# Altium schematic sheet Monitor Blanking V3.SchDoc
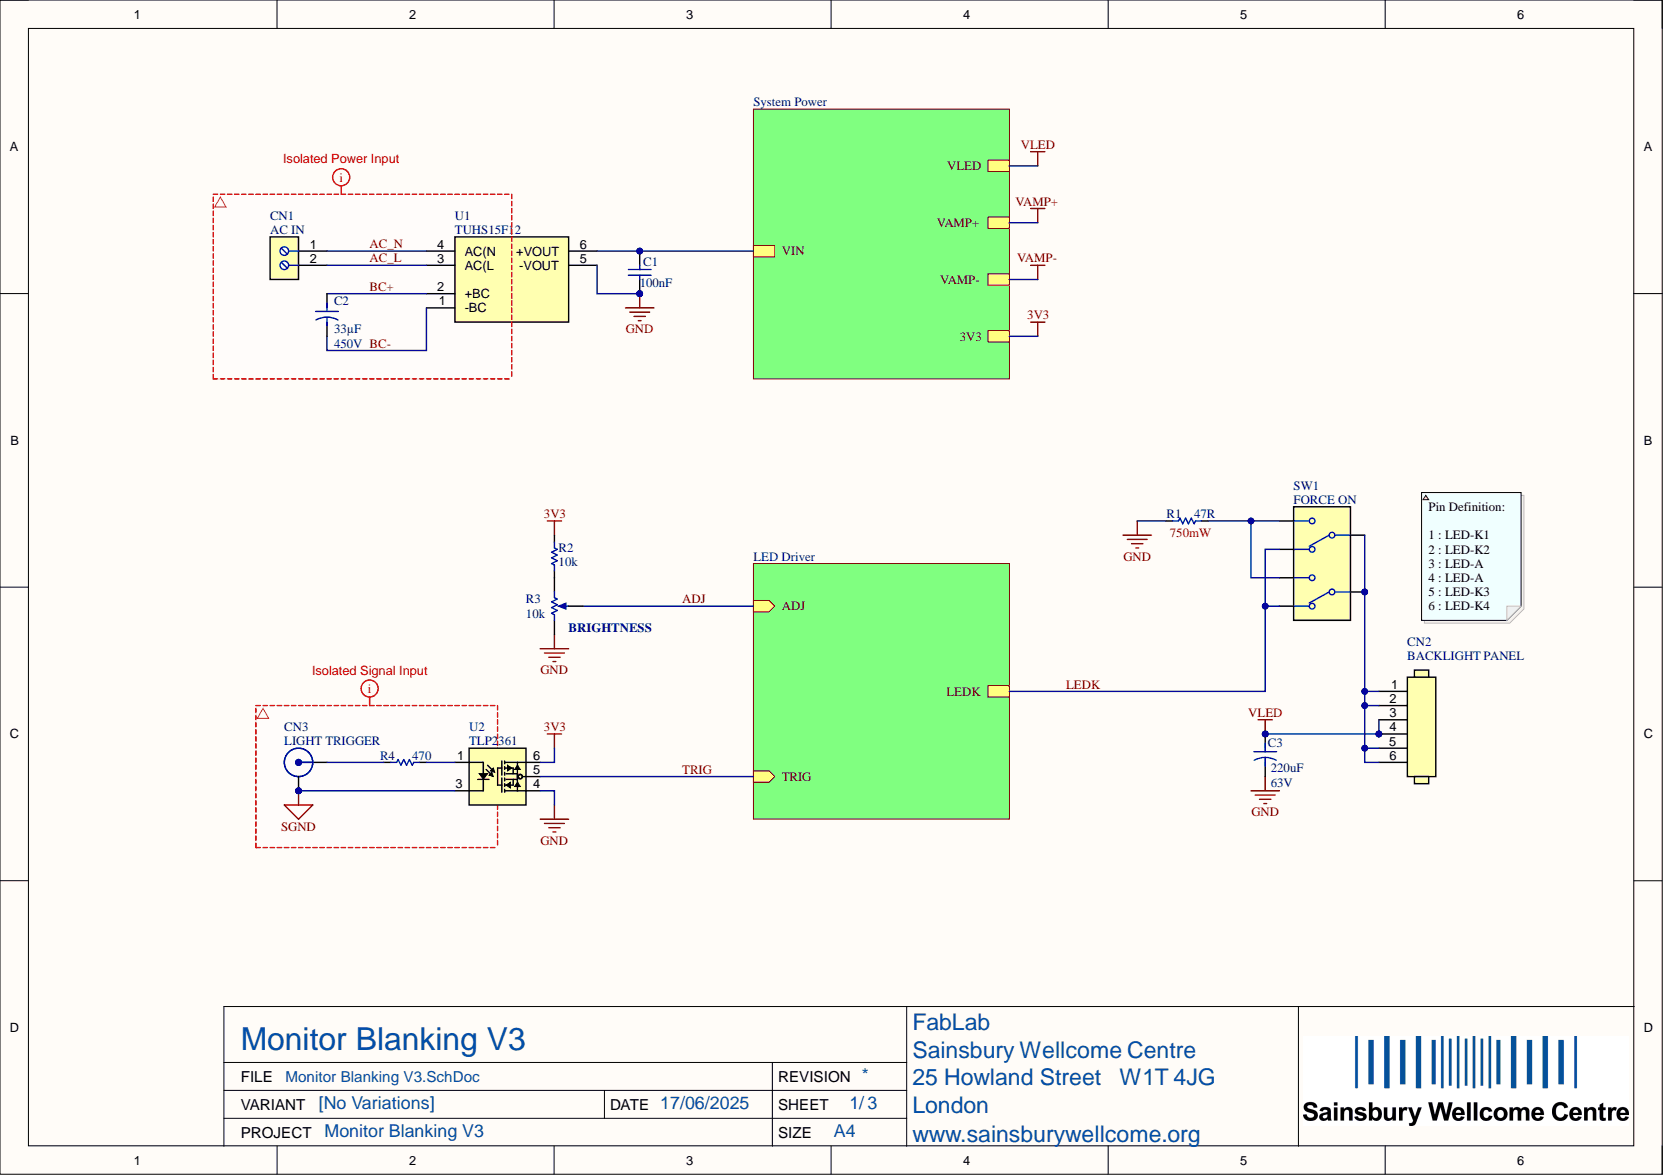
[diagram p0: Monitor Blanking V3.SchDoc]

## Parts
C1  value 100nF  [CAP_CHIP_0603]  (hidden comment: C0603-100nF-50V)
C2  value 33µF  Voltage Rating: 450V  [ECAP_DIP_12.5_90]  (hidden comment: EC125-33uF-450V)
C3  value 220uF  Voltage Rating: 63V  [ECAP_SMD_D12.5_13.5L]  (hidden comment: EC125-220uF-63V)
CN1  AC IN  [BT-8.26-1X2P]
CN2  BACKLIGHT PANEL  [PH_DIP_90_1X6P]
CN3  LIGHT TRIGGER  [BNC-TE-5227222]
R1  value 47R  Power Rating: 750mW  [RES_CHIP_2010]  (hidden comment: R2010-47R)
R2  value 10k  [RES_CHIP_0603]  (hidden comment: R0603-10K)
R3  BRIGHTNESS  value 10k  [RK09L1140]
R4  value 470  [RES_CHIP_0603]  (hidden comment: R0603-470R)
SW1  FORCE ON  [SW_LOCK_SPPH410100]
U1  TUHS15F12  [TUHS15F12]
U2  TLP2361  [SO5]

## Nets
3V3: R2.2 U2.6
GND: C1.1 C3.2 R1.1 R3.1 U1.5(-VOUT) U2.4
SGND: CN3.2 U2.3
VLED: C3.1 CN2.3 CN2.4
AC_L: CN1.2 U1.3(AC(L)
AC_N: CN1.1 U1.4(AC(N)
ADJ: R3.2
BC+: C2.1 U1.2(+BC)
BC-: C2.2 U1.1(-BC)
LEDK: SW1.1 SW1.4
TRIG: U2.5
NetC1_2: C1.2 U1.6(+VOUT)
NetCN2_1: CN2.1 CN2.2 CN2.5 CN2.6 SW1.2 SW1.5
NetCN3_1: CN3.1 R4.1
NetR1_2: R1.2 SW1.3 SW1.6
NetR2_1: R2.1 R3.3
NetR4_2: R4.2 U2.1
VAMP+:
VAMP-:

## Library data of the parts
C1 = CMP-611-0027-24: 0603 Multilayer Ceramic Capacitors MLCC; PartNumber: CC0603KRX7R9BB104; Dielectric: X7R; Pick&PlaceFootprint: C0603; Tolerance: 10%; Voltage Rating: 50V; Capacitor Type: Ceramic; Case/Package: 0603; Component Kind: Standard; LCSC Part N.: C14663; Mount: SMD; RoHS: Yes; Library Name: Sainsbury Wellcome Centre
C2 = CMP-612-0013-1: Aluminum Electrolytic Capacitor, Polarized, Aluminum (wet), 450V, 20% +Tol, 20% -Tol, 33uF, Through Hole Mount; PartNumber: 450BXW33MEFR12.5X25; Capacitor Type: Aluminium; Component Kind: Standard; LCSC Part N.: C1589601; Mount: TH; Tolerance: 20%; Library Name: Sainsbury Wellcome Centre
C3 = CMP-612-0011-1: Radial Can - SMD ALUMINUM ELECTROLYTIC CAPACITOR FK 63V 220muF 20% 0.492Dia 12.50mm; PartNumber: EEEFK1J221AQ; ESR (Equivalent Series Resistance): 160mR; Ripple Current: 800mA; Capacitor Type: Electrolytic; Component Kind: Standard; LCSC Part N.: C215762; Tolerance: 20%; Library Name: Sainsbury Wellcome Centre
CN1 = CMP-330-0001-1: CONN BARRIER STRIP 2CIRC 0.325"; PartNumber: 4DB-P108-02; Current Rating: 20A; Gender: Male; Max Current Rating: 10A; Number of Contacts: 2; Pitch: 8.26mm; Voltage Rating: 300V; Component Kind: Standard; LCSC Part N.: C5902324; Number of Positions: 2; Number of Rows: 1; Orientation: Straight; Library Name: Sainsbury Wellcome Centre
CN2 = CMP-370-0048-1: Wire-To-Board Connector, Right Angle, 2 mm, 2 Contacts, Header, PH Series; PartNumber: X2016WR-06-46SN; Gender: Male; Max Current Rating: 2A; Max Voltage Rating (DC): 100V; Component Kind: Standard; LCSC Part N.: C696999; Lead Pitch: 2mm; Mount: Through; Orientation: Right Angle; Library Name: Sainsbury Wellcome Centre
CN3 = CMP-730-0010-3: RF BNC Connector, Female, Panel Mount, Board Mount, Solder Terminal, Jack; PartNumber: 5227222-3; Characteristic Impedance: 50R; Clock Frequency: 4GHz; Connector Type: BNC,Jack; Component Kind: Standard; Contact Material: Bronze; Gender: Female; LCSC Part N.: C3175614; Mount: Surface Mount; Orientation: Straight; Library Name: Sainsbury Wellcome Centre
R1 = CMP-633-0165-2: Res Thick Film 2010 47 Ohm 1% 3/4W ±100ppm/°C Molded SMD SMD Embossed T/R; PartNumber: RC2010FK-0747RL; Number of Resistors: 1; Pick&PlaceFootprint: 2010; Voltage Rating: 200V; Component Kind: Standard; LCSC Part N.: C877463; Mount: Surface Mount; Tolerance: 1%; Library Name: Sainsbury Wellcome Centre
R2 = CMP-633-0002-26: 100mW Thick Film Resistors 75V ±100ppm/℃ ±1% 0603 Chip Resistor; PartNumber: 0603WAF1002T5E; Number of Resistors: 1; Pick&PlaceFootprint: R0603; Case/Package: 0603; Component Kind: Standard; LCSC Part N.: C25804; Mount: Surface Mount; Power Rating: 100mW; Tolerance: 1%; Voltage Rating: 75V; Library Name: Sainsbury Wellcome Centre
R3 = CMP-632-0008-2: Potentiometer, 0.05W, 10000ohm, 10V, 20% +/-Tol, 1 Turn(s), 4845; PartNumber: RK09L114001T; Number of Turns: 1; Power Rating: 50mW; Case/Package: 4845; Component Kind: Standard; LCSC Part N.: C470463; Mount: TH; RoHS: Yes; Tolerance: 20%; Type: Potentiometer; Library Name: Sainsbury Wellcome Centre
R4 = CMP-633-0020-24: 100mW Thick Film Resistors 75V ±100ppm/℃ ±1% 0603 Chip Resistor; PartNumber: 0603WAF4700T5E; Number of Resistors: 1; Pick&PlaceFootprint: R0603; Case/Package: 0603; Component Kind: Standard; LCSC Part N.: C23179; Mount: Surface Mount; Power Rating: 100mW; Tolerance: 1%; Voltage Rating: 75V; Library Name: Sainsbury Wellcome Centre
SW1 = CMP-B00-0031-1: Switch Push Button N.O./N.C. DPDT Plunger 0.1A 30VDC Latching PC Pins Thru-Hole Bulk; PartNumber: SPPH410100; Contact Resistance: 100mR; Current Rating: 100mA; Operating Force: 2N; Voltage Rating (DC): 30V; Component Kind: Standard; LCSC Part N.: C370973; Throw Configuration: DPDT; Type: Latching; Library Name: Sainsbury Wellcome Centre
U1 = CMP-A10-0017-1: AC/DC 15W 12V Single Output Power Supply, Encapsulated Board Mount Module; Efficiency: 85%; Max Output current: 1.25A; Number of Outputs: 1; Component Kind: Standard; LCSC Part N.: C3031238; Max Power Dissipation: 15W; PartNumber: TUHS15F12; Voltage - Output 1: 12V; Library Name: Sainsbury Wellcome Centre
U2 = CMP-530-0005-1: Logic IC Output Optocoupler, 1-Channel, 1-Element, 3750V Isolation, 20MBps; PartNumber: TLP2361(TPL,E(T; Direction: Unidirectional; Fall Time: 3ns; Forward Current: 10mA; Forward Voltage: 1.5V; Propagation Delay: 80ns; Component Kind: Standard; LCSC Part N.: C107626; Output Current per Channel: 10mA; Library Name: Sainsbury Wellcome Centre
